# Altium schematic sheet Power_VCC.SchDoc("Power VCC")
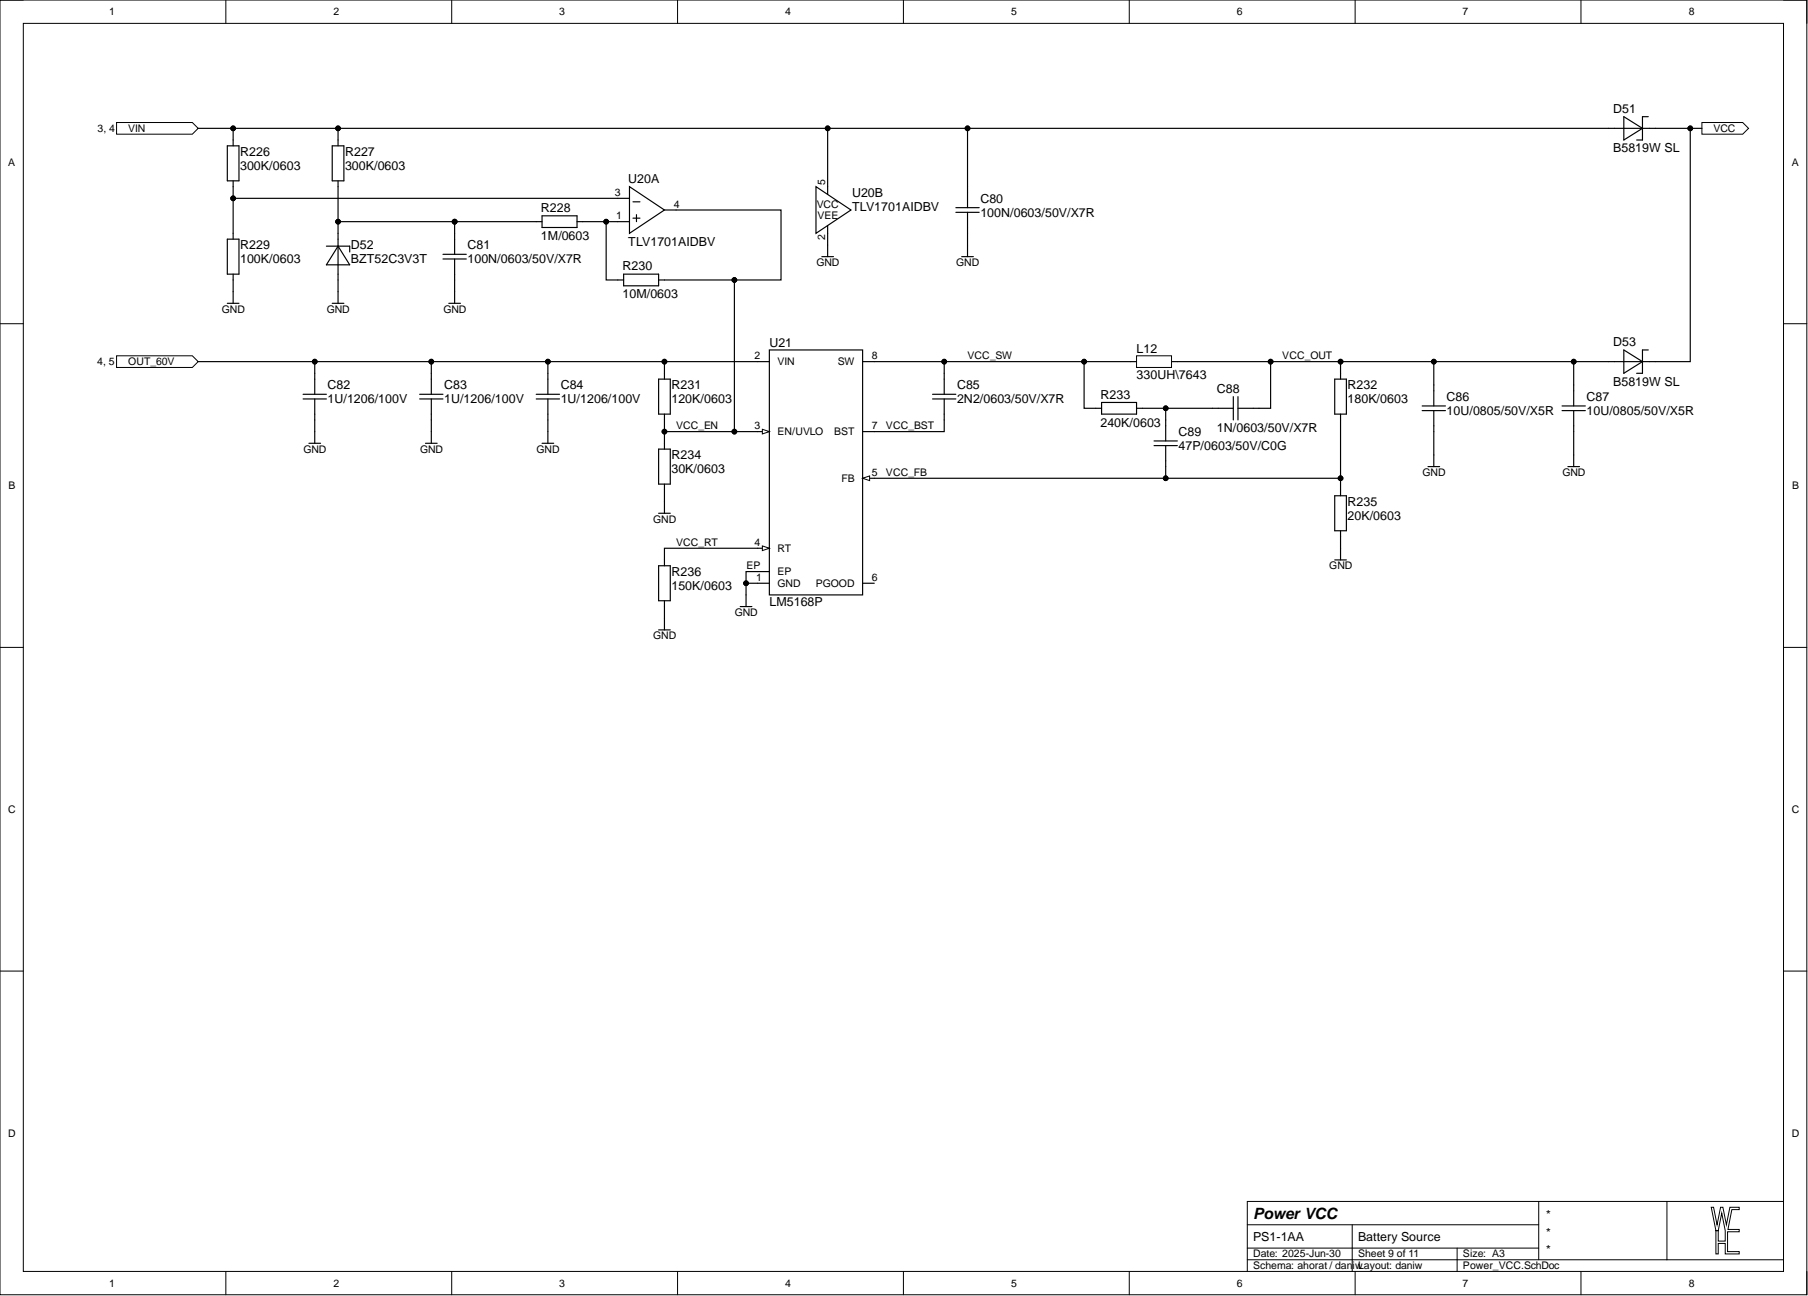
[diagram p0: Power_VCC.SchDoc]

## Parts
C80  100N/0603/50V/X7R  [CAP0603_X7R]
C81  100N/0603/50V/X7R  [CAP0603_X7R]
C82  1U/1206/100V  [CAP1206-X7R]
C83  1U/1206/100V  [CAP1206-X7R]
C84  1U/1206/100V  [CAP1206-X7R]
C85  2N2/0603/50V/X7R  [CAP0603_X7R]
C86  10U/0805/50V/X5R  [CAP0805_X7R]
C87  10U/0805/50V/X5R  [CAP0805_X7R]
C88  1N/0603/50V/X7R  [CAP0603_X7R]
C89  47P/0603/50V/C0G  [CAP0603_C0G]
D51  B5819W SL  [SOD3718X125]
D52  BZT52C3V3T  [SOD1608X065]
D53  B5819W SL  [SOD3718X125]
L12  330UH\7643  [INDM7676X43]
R226  300K/0603  [R0603-0.40-WW]
R227  300K/0603  [R0603-0.40-WW]
R228  1M/0603  [R0603-0.40-WW]
R229  100K/0603  [R0603-0.40-WW]
R230  10M/0603  [R0603-0.40-WW]
R231  120K/0603  [R0603-0.40-WW]
R232  180K/0603  [R0603-0.40-WW]
R233  240K/0603  [R0603-0.40-WW]
R234  30K/0603  [R0603-0.40-WW]
R235  20K/0603  [R0603-0.40-WW]
R236  150K/0603  [R0603-0.40-WW]
U20  TLV1701AIDBV  [SOT2395P280X125-5-NW]  (parts A,B)
U21  LM5168P  [SOP127P600X155-8-EP330x240-NW]

## Ports
OUT_60V, VCC, VIN

## Nets
GND: C80.2 C81.2 C82.2 C83.2 C84.2 C86.2 C87.2 D52.2 R229.2 R234.2 R235.2 R236.2 U20.2 U21.1(GND) U21.EP
OUT_60V: C82.1 C83.1 C84.1 R231.1 U21.2(VIN) ; port OUT_60V
VCC: D51.1 D53.1 ; port VCC
VCC_BST: C85.2 U21.7(BST)
VCC_EN: R230.2 R231.2 R234.1 U20.4 U21.3(EN/UVLO)
VCC_FB: C89.2 R232.2 R235.1 U21.5(FB)
VCC_OUT: C86.1 C87.1 C88.2 D53.2 L12.2 R232.1
VCC_RT: R236.1 U21.4(RT)
VCC_SW: C85.1 L12.1 R233.1 U21.8(SW)
VIN: C80.1 D51.2 R226.1 R227.1 U20.5 ; port VIN
NetC81_1: C81.1 D52.1 R227.2 R228.1
NetC88_1: C88.1 C89.1 R233.2
NetR226_2: R226.2 R229.1 U20.3
NetR228_2: R228.2 R230.1 U20.1
NetU21_6: U21.6(PGOOD)

## Library data of the parts
C80 C81 = 100N/0603/50V/X7R: CAP SM 0603 100nF 50V X7R; Manufacturer: GENERIC; Manufacturer 1: YAGEO; Manufacturer Part Number 1: CC0603KPX7R9BB104; Absolute Value: 1E-7; Database Table Name: C$; Eurocircuits GPN: GPC0603104; Component Kind: Standard; HelpURL: https://www.yageo.com/upload/media/product/app/datasheet/mlcc/upy-gphc_x7r_6_3v-to-250v.pdf; JLC Type: Basic; Manufacturer 2: YAGEO; Manufacturer Part Number 2: CC0603MRX7R9BB104; Manufacturer Part Number 3: CC0603KRX7R9BB104; Package: 0603; Part Number: 100N/0603/50V/X7R; Supplier 1: Digi-Key; Supplier 2: Digi-Key; Supplier 3: LCSC; Supplier Part Number 1: 311-3567-1-ND; Supplier Part Number 2: 311-4110-1-ND; Supplier Part Number 3: C14663; Value: 100nF; Library Name: daniw_altium_lib.DbLib
C82-C84 = 1U/1206/100V: CAP SM 1206 1uF 100V; Manufacturer: GENERIC; Manufacturer 1: Samsung Electro-Mechanics; Manufacturer Part Number 1: CL31B105KCHNNNE; Absolute Value: 1E-6; Database Table Name: C$; Component Kind: Standard; HelpURL: https://mm.digikey.com/Volume0/opasdata/d220001/medias/docus/41/CL31B105KCHNNNE_Spec.pdf; Package: 1206; Part Number: 1U/1206/100V; Supplier 1: Digi-Key; Supplier Part Number 1: 1276-1838-1-ND; Value: 1UF; Library Name: daniw_altium_lib.DbLib
C85 = 2N2/0603/50V/X7R: CAP SM 0603 2.2nF 50V X7R; Manufacturer: GENERIC; Manufacturer 1: YAGEO; Manufacturer Part Number 1: CC0603KPX7R9BB222; Absolute Value: 2.2E-9; Database Table Name: C$; Eurocircuits GPN: GPC0603222; Component Kind: Standard; HelpURL: https://www.yageo.com/upload/media/product/app/datasheet/mlcc/upy-gphc_x7r_6_3v-to-250v.pdf; JLC Type: Basic; Manufacturer 2: YAGEO; Manufacturer Part Number 2: CC0603KRX7R9BB222; Manufacturer Part Number 3: 0603B222K500NT; Package: 0603; Part Number: 2N2/0603/50V/X7R; Supplier 1: Digi-Key; Supplier 2: Digi-Key; Supplier 3: LCSC; Supplier Part Number 1: 13-CC0603KPX7R9BB222CT-ND; Supplier Part Number 2: 311-1081-1-ND; Supplier Part Number 3: C1604; Value: 2.2nF; Library Name: daniw_altium_lib.DbLib
C86 C87 = 10U/0805/50V/X5R: CAP SM 0805 10uF 50V X5R; Manufacturer: GENERIC; Manufacturer 1: Murata Electronics; Manufacturer Part Number 1: GRM21BR61H106KE43K; Absolute Value: 1E-5; Database Table Name: C$; Eurocircuits GPN: GPN0805106-50-X5R; Component Kind: Standard; HelpURL: https://search.murata.co.jp/Ceramy/image/img/A01X/G101/ENG/GRM21BR61H106KE43-01A.pdf; JLC Type: Basic; Manufacturer Part Number 3: GRM21BR61H106KE43L; Package: 0805; Part Number: 10U/0805/50V/X5R; Supplier 1: Digi-Key; Supplier 3: LCSC; Supplier Part Number 1: 490-GRM21BR61H106KE43KCT-ND; Supplier Part Number 3: C440198; Value: 10uF; Library Name: daniw_altium_lib.DbLib
C88 = 1N/0603/50V/X7R: CAP SM 0603 1.0nF 50V X7R; Manufacturer: GENERIC; Manufacturer 1: YAGEO; Manufacturer Part Number 1: CC0603KPX7R9BB102; Absolute Value: 1E-9; Database Table Name: C$; Eurocircuits GPN: GPC0603102; Component Kind: Standard; HelpURL: https://www.yageo.com/upload/media/product/app/datasheet/mlcc/upy-gphc_x7r_6_3v-to-250v.pdf; JLC Type: Basic; Manufacturer 2: YAGEO; Manufacturer Part Number 2: CC0603KRX7R9BB102; Manufacturer Part Number 3: CL10B102KB8NNNC; Package: 0603; Part Number: 1N/0603/50V/X7R; Supplier 1: Digi-Key; Supplier 2: Digi-Key; Supplier 3: LCSC; Supplier Part Number 1: 13-CC0603KPX7R9BB102CT-ND; Supplier Part Number 2: 311-1080-1-ND; Supplier Part Number 3: C1588; Value: 1.0nF; Library Name: daniw_altium_lib.DbLib
C89 = 47P/0603/50V/C0G: CAP SM 0603 47pF 50V C0G; Manufacturer: GENERIC; Manufacturer 1: YAGEO; Manufacturer Part Number 1: CC0603JRNPO9BN470; Absolute Value: 4.7E-11; Database Table Name: C$; Eurocircuits GPN: GPC0603470-C0G; Component Kind: Standard; HelpURL: https://www.yageo.com/upload/media/product/productsearch/datasheet/mlcc/UPY-GP_NP0_16V-to-50V_18.pdf; JLC Type: Basic; Manufacturer 2: YAGEO; Manufacturer Part Number 2: CC0603KRNPO9BN470; Manufacturer Part Number 3: CL10C470JB8NNNC; Package: 0603; Part Number: 47P/0603/50V/C0G; Supplier 1: Digi-Key; Supplier 2: Digi-Key; Supplier 3: LCSC; Supplier Part Number 1: 311-1065-1-ND; Supplier Part Number 2: 311-4037-1-ND; Supplier Part Number 3: C1671; Value: 47pF; Library Name: daniw_altium_lib.DbLib
D51 D53 = B5819W SL: 40V 600mV@1A 1A SOD-123 Schottky Barrier Diodes; Manufacturer: GENERIC; Manufacturer 1: SMC Diode Solutions; Database Table Name: D$; Absolute Value: 40V; Component Kind: Standard; HelpURL: https://datasheet.lcsc.com/lcsc/2304140030_Jiangsu-Changjing-Electronics-Technology-Co---Ltd--B5819W-SL_C8598.pdf; JLC Type: Basic; Manufacturer 3: Jiangsu Changjing Electronics Technology Co., Ltd.; Manufacturer Part Number 1: B5819WSL; Manufacturer Part Number 3: B5819W SL; Package: SOD-123; Part Number: B5819W SL; Supplier 1: Digikey; Supplier 3: LCSC; Supplier Part Number 1: 1655-B5819WSLCT-ND; Supplier Part Number 3: C8598; Value: B5819W SL; Library Name: daniw_altium_lib.DbLib
D52 = BZT52C3V3T: DIODE ZENER 3.3V 300MW SOD523; Manufacturer: Diodes Incorporated; Manufacturer Part Number 1: BZT52C3V3T-7; Database Table Name: D$; Absolute Value: 3.3 V; Component Kind: Standard; HelpURL: https://www.diodes.com/assets/Datasheets/ds30502.pdf; Manufacturer 1: Diodes Incorporated; Manufacturer 2: Micro Commercial Co; Manufacturer 3: BORN; Manufacturer Part Number 2: BZT52C3V3T-TP; Manufacturer Part Number 3: BZT52C3V3T; Package: SC-79, SOD-523; Part Number: BZT52C3V3T; Supplier 1: Digikey; Supplier 2: Digikey; Supplier 3: LCSC; Supplier Part Number 1: BZT52C3V3T-7DICT-ND; Supplier Part Number 2: BZT52C3V3T-TPMSCT-ND; Supplier Part Number 3: C20612286; Value: BZT52C3V3T; Library Name: daniw_altium_lib.DbLib
L12 = 330UH\7643: FIXED IND 330UH 450MA 1.41OHM SM; Manufacturer: Eaton - Electronics Division; Manufacturer Part Number 1: DR74-331-R; Absolute Value: 0.00033; Database Table Name: L$; Component Kind: Standard; HelpURL: https://www.eaton.com/content/dam/eaton/products/electronic-components/resources/data-sheet/eaton-dr-high-power-density-high-efficiency-shielded-drum-core-power-inductors-data-sheet.pdf; Manufacturer 1: Eaton - Electronics Division; Manufacturer 3: SXN(Shun Xiang Nuo Elec); Manufacturer Part Number 3: SMDRI74-331MT; Package: 7643; Part Number: 330UH\7643; Supplier 1: Digi-Key; Supplier 3: LCSC; Supplier Part Number 1: 283-4601-1-ND; Supplier Part Number 3: C35560; Value: 330UH; Library Name: daniw_altium_lib.DbLib
R226 R227 = 300K/0603: RES SM 0603 300K; Manufacturer: GENERIC; Manufacturer 1: YAGEO; Manufacturer Part Number 1: RC0603FR-13300KL; Absolute Value: 300000; Database Table Name: R$; Eurocircuits GPN: GPR0603300K; Component Kind: Standard; HelpURL: https://www.yageo.com/upload/media/product/productsearch/datasheet/rchip/PYu-RC_Group_51_RoHS_L_12.pdf; JLC Type: Basic; Manufacturer 2: YAGEO; Manufacturer 3: UNI-ROYAL(Uniroyal Elec); Manufacturer Part Number 2: RC0603FR-10300KL; Manufacturer Part Number 3: 0603WAF3003T5E; Package: 0603; Part Number: 300K/0603; Supplier 1: Digi-Key; Supplier 2: Digi-Key; Supplier 3: LCSC; Supplier Part Number 1: 13-RC0603FR-13300KLCT-ND; Supplier Part Number 2: 13-RC0603FR-10300KLCT-ND; Supplier Part Number 3: C23024; Value: 300K; Library Name: daniw_altium_lib.DbLib
R228 = 1M/0603: RES SM 0603 1M; Manufacturer: GENERIC; Manufacturer 1: YAGEO; Manufacturer Part Number 1: RC0603FR-131ML; Absolute Value: 1000000; Database Table Name: R$; Eurocircuits GPN: GPR06031M; Component Kind: Standard; HelpURL: https://www.yageo.com/upload/media/product/app/datasheet/rchip/pyu-rc_group_51_rohs_l.pdf; JLC Type: Basic; Manufacturer 2: YAGEO; Manufacturer 3: UNI-ROYAL(Uniroyal Elec); Manufacturer Part Number 2: RC0603FR-101ML; Manufacturer Part Number 3: 0603WAF1004T5E; Package: 0603; Part Number: 1M/0603; Supplier 1: Digi-Key; Supplier 2: Digi-Key; Supplier 3: LCSC; Supplier Part Number 1: 13-RC0603FR-131MLCT-ND; Supplier Part Number 2: 13-RC0603FR-101MLCT-ND; Supplier Part Number 3: C22935; Value: 1M; Library Name: daniw_altium_lib.DbLib
R229 = 100K/0603: RES SM 0603 100K; Manufacturer: GENERIC; Manufacturer 1: YAGEO; Manufacturer Part Number 1: RC0603FR-13100KL; Absolute Value: 100000; Database Table Name: R$; Eurocircuits GPN: GPR0603100K; Component Kind: Standard; HelpURL: https://www.yageo.com/upload/media/product/productsearch/datasheet/rchip/PYu-RC_Group_51_RoHS_L_12.pdf; JLC Type: Basic; Manufacturer 2: YAGEO; Manufacturer 3: UNI-ROYAL(Uniroyal Elec); Manufacturer Part Number 2: RC0603FR-10100KL; Manufacturer Part Number 3: 0603WAF1003T5E; Package: 0603; Part Number: 100K/0603; Supplier 1: Digi-Key; Supplier 2: Digi-Key; Supplier 3: LCSC; Supplier Part Number 1: 13-RC0603FR-13100KLCT-ND; Supplier Part Number 2: 13-RC0603FR-10100KLCT-ND; Supplier Part Number 3: C25803; Value: 100K; Library Name: daniw_altium_lib.DbLib
R230 = 10M/0603: RES SM 0603 10M; Manufacturer: GENERIC; Manufacturer 1: YAGEO; Manufacturer Part Number 1: RC0603FR-1310ML; Absolute Value: 10000000; Database Table Name: R$; Eurocircuits GPN: GPR060310M; Component Kind: Standard; HelpURL: https://www.yageo.com/upload/media/product/productsearch/datasheet/rchip/PYu-RC_Group_51_RoHS_L_12.pdf; JLC Type: Basic; Manufacturer 2: YAGEO; Manufacturer 3: UNI-ROYAL(Uniroyal Elec); Manufacturer Part Number 2: RC0603FR-1010ML; Manufacturer Part Number 3: 0603WAF1005T5E; Package: 0603; Part Number: 10M/0603; Supplier 1: Digi-Key; Supplier 2: Digi-Key; Supplier 3: LCSC; Supplier Part Number 1: 13-RC0603FR-1310MLCT-ND; Supplier Part Number 2: 13-RC0603FR-1010MLCT-ND; Supplier Part Number 3: C7250; Value: 10M; Library Name: daniw_altium_lib.DbLib
R231 = 120K/0603: RES SM 0603 120K; Manufacturer: GENERIC; Manufacturer 1: YAGEO; Manufacturer Part Number 1: RC0603FR-13120KL; Absolute Value: 120000; Database Table Name: R$; Eurocircuits GPN: GPR0603120K; Component Kind: Standard; HelpURL: https://www.yageo.com/upload/media/product/app/datasheet/rchip/pyu-rc_group_51_rohs_l.pdf; JLC Type: Basic; Manufacturer 2: YAGEO; Manufacturer 3: UNI-ROYAL(Uniroyal Elec); Manufacturer Part Number 2: RC0603FR-10120KL; Manufacturer Part Number 3: 0603WAF1203T5E; Package: 0603; Part Number: 120K/0603; Supplier 1: Digi-Key; Supplier 2: Digi-Key; Supplier 3: LCSC; Supplier Part Number 1: 13-RC0603FR-13120KLCT-ND; Supplier Part Number 2: 13-RC0603FR-10120KLCT-ND; Supplier Part Number 3: C25808; Value: 120K; Library Name: daniw_altium_lib.DbLib
R232 = 180K/0603: RES SM 0603 180K; Manufacturer: GENERIC; Manufacturer 1: YAGEO; Manufacturer Part Number 1: RC0603FR-13180KL; Absolute Value: 180000; Database Table Name: R$; Eurocircuits GPN: GPR0603180K; Component Kind: Standard; HelpURL: https://www.yageo.com/upload/media/product/productsearch/datasheet/rchip/PYu-RC_Group_51_RoHS_L_12.pdf; JLC Type: Extended; Manufacturer 2: YAGEO; Manufacturer 3: UNI-ROYAL(Uniroyal Elec); Manufacturer Part Number 2: RC0603FR-10180KL; Manufacturer Part Number 3: 0603WAF1803T5E; Package: 0603; Part Number: 180K/0603; Supplier 1: Digi-Key; Supplier 2: Digi-Key; Supplier 3: LCSC; Supplier Part Number 1: 13-RC0603FR-13180KLCT-ND; Supplier Part Number 2: 13-RC0603FR-10180KLCT-ND; Supplier Part Number 3: C22827; Value: 180K; Library Name: daniw_altium_lib.DbLib
R233 = 240K/0603: RES SM 0603 240K; Manufacturer: GENERIC; Manufacturer 1: YAGEO; Manufacturer Part Number 1: RC0603FR-13240KL; Absolute Value: 240000; Database Table Name: R$; Eurocircuits GPN: GPR0603240K; Component Kind: Standard; HelpURL: https://www.yageo.com/upload/media/product/productsearch/datasheet/rchip/PYu-RC_Group_51_RoHS_L_12.pdf; JLC Type: Extended; Manufacturer 2: YAGEO; Manufacturer 3: UNI-ROYAL(Uniroyal Elec); Manufacturer Part Number 2: RC0603FR-07240KL; Manufacturer Part Number 3: 0603WAF2403T5E; Package: 0603; Part Number: 240K/0603; Supplier 1: Digi-Key; Supplier 2: Digi-Key; Supplier 3: LCSC; Supplier Part Number 1: 13-RC0603FR-13240KLCT-ND; Supplier Part Number 2: 311-240KHRCT-ND; Supplier Part Number 3: C4197; Value: 240K; Library Name: daniw_altium_lib.DbLib
R234 = 30K/0603: RES SM 0603 30K; Manufacturer: GENERIC; Manufacturer 1: YAGEO; Manufacturer Part Number 1: RC0603FR-1330KL; Absolute Value: 30000; Database Table Name: R$; Eurocircuits GPN: GPR060330K; Component Kind: Standard; HelpURL: https://www.yageo.com/upload/media/product/app/datasheet/rchip/pyu-rc_group_51_rohs_l.pdf; JLC Type: Basic; Manufacturer 2: YAGEO; Manufacturer 3: UNI-ROYAL(Uniroyal Elec); Manufacturer Part Number 2: RC0603FR-1030KL; Manufacturer Part Number 3: 0603WAF3002T5E; Package: 0603; Part Number: 30K/0603; Supplier 1: Digi-Key; Supplier 2: Digi-Key; Supplier 3: LCSC; Supplier Part Number 1: 13-RC0603FR-1330KLCT-ND; Supplier Part Number 2: 13-RC0603FR-1030KLCT-ND; Supplier Part Number 3: C22984; Value: 30K; Library Name: daniw_altium_lib.DbLib
R235 = 20K/0603: RES SM 0603 20K; Manufacturer: GENERIC; Manufacturer 1: YAGEO; Manufacturer Part Number 1: RC0603FR-1320KL; Absolute Value: 20000; Database Table Name: R$; Eurocircuits GPN: GPR060320K; Component Kind: Standard; HelpURL: https://www.yageo.com/upload/media/product/app/datasheet/rchip/pyu-rc_group_51_rohs_l.pdf; JLC Type: Basic; Manufacturer 2: YAGEO; Manufacturer 3: UNI-ROYAL(Uniroyal Elec); Manufacturer Part Number 2: RC0603FR-1020KL; Manufacturer Part Number 3: 0603WAF2002T5E; Package: 0603; Part Number: 20K/0603; Supplier 1: Digi-Key; Supplier 2: Digi-Key; Supplier 3: LCSC; Supplier Part Number 1: 13-RC0603FR-1320KLCT-ND; Supplier Part Number 2: 13-RC0603FR-1020KLCT-ND; Supplier Part Number 3: C4184; Value: 20K; Library Name: daniw_altium_lib.DbLib
R236 = 150K/0603: RES SM 0603 150K; Manufacturer: GENERIC; Manufacturer 1: YAGEO; Manufacturer Part Number 1: RC0603FR-13150KL; Absolute Value: 150000; Database Table Name: R$; Eurocircuits GPN: GPR0603150K; Component Kind: Standard; HelpURL: https://www.yageo.com/upload/media/product/app/datasheet/rchip/pyu-rc_group_51_rohs_l.pdf; JLC Type: Basic; Manufacturer 2: YAGEO; Manufacturer 3: UNI-ROYAL(Uniroyal Elec); Manufacturer Part Number 2: RC0603FR-10150KL; Manufacturer Part Number 3: 0603WAF1503T5E; Package: 0603; Part Number: 150K/0603; Supplier 1: Digi-Key; Supplier 2: Digi-Key; Supplier 3: LCSC; Supplier Part Number 1: 13-RC0603FR-13150KLCT-ND; Supplier Part Number 2: 13-RC0603FR-10150KLCT-ND; Supplier Part Number 3: C22807; Value: 150K; Library Name: daniw_altium_lib.DbLib
U20 = TLV1701 SOT23: TLV1701 2.2-V to 36-V, microPower Comparato; Manufacturer: Texas Instruments; Manufacturer Part Number 1: TLV1701AIDBVR; Database Table Name: Integrated$; Component Kind: Standard; HelpURL: https://www.ti.com/lit/ds/symlink/tlv1701.pdf; Manufacturer 1: Texas Instruments; Manufacturer 2: Texas Instruments; Manufacturer 3: Texas Instruments; Manufacturer Part Number 2: TLV1701QDBVRQ1; Manufacturer Part Number 3: TLV1701AIDBVR; Package: SOT23-5; Part Number: TLV1701 SOT23; Supplier 1: Digi-Key; Supplier 2: Digi-Key; Supplier 3: LCSC; Supplier Part Number 1: 296-40599-1-ND; Supplier Part Number 2: 296-47458-1-ND; Supplier Part Number 3: C130035; Library Name: daniw_altium_lib.DbLib
U21 = LM5168P: 0.3A, 120V Absolute Maximum, Step-Down Converter With Fly-Buck™ Converter Capability; Manufacturer: Texas Instruments; Manufacturer Part Number 1: LM5168PDDAR; Database Table Name: Integrated$; Component Kind: Standard; HelpURL: https://www.ti.com/lit/ds/symlink/lm5168.pdf; Manufacturer 1: Texas Instruments; Manufacturer 3: Texas Instruments; Manufacturer Part Number 3: LM5168PDDAR; Package: SO8-EP; Part Number: LM5168P; Supplier 1: Digi-Key; Supplier 3: LCSC; Supplier Part Number 1: 296-LM5168PDDARCT-ND; Supplier Part Number 3: C5751958; Library Name: daniw_altium_lib.DbLib
